# Altium schematic sheet Power12_2.SchDoc
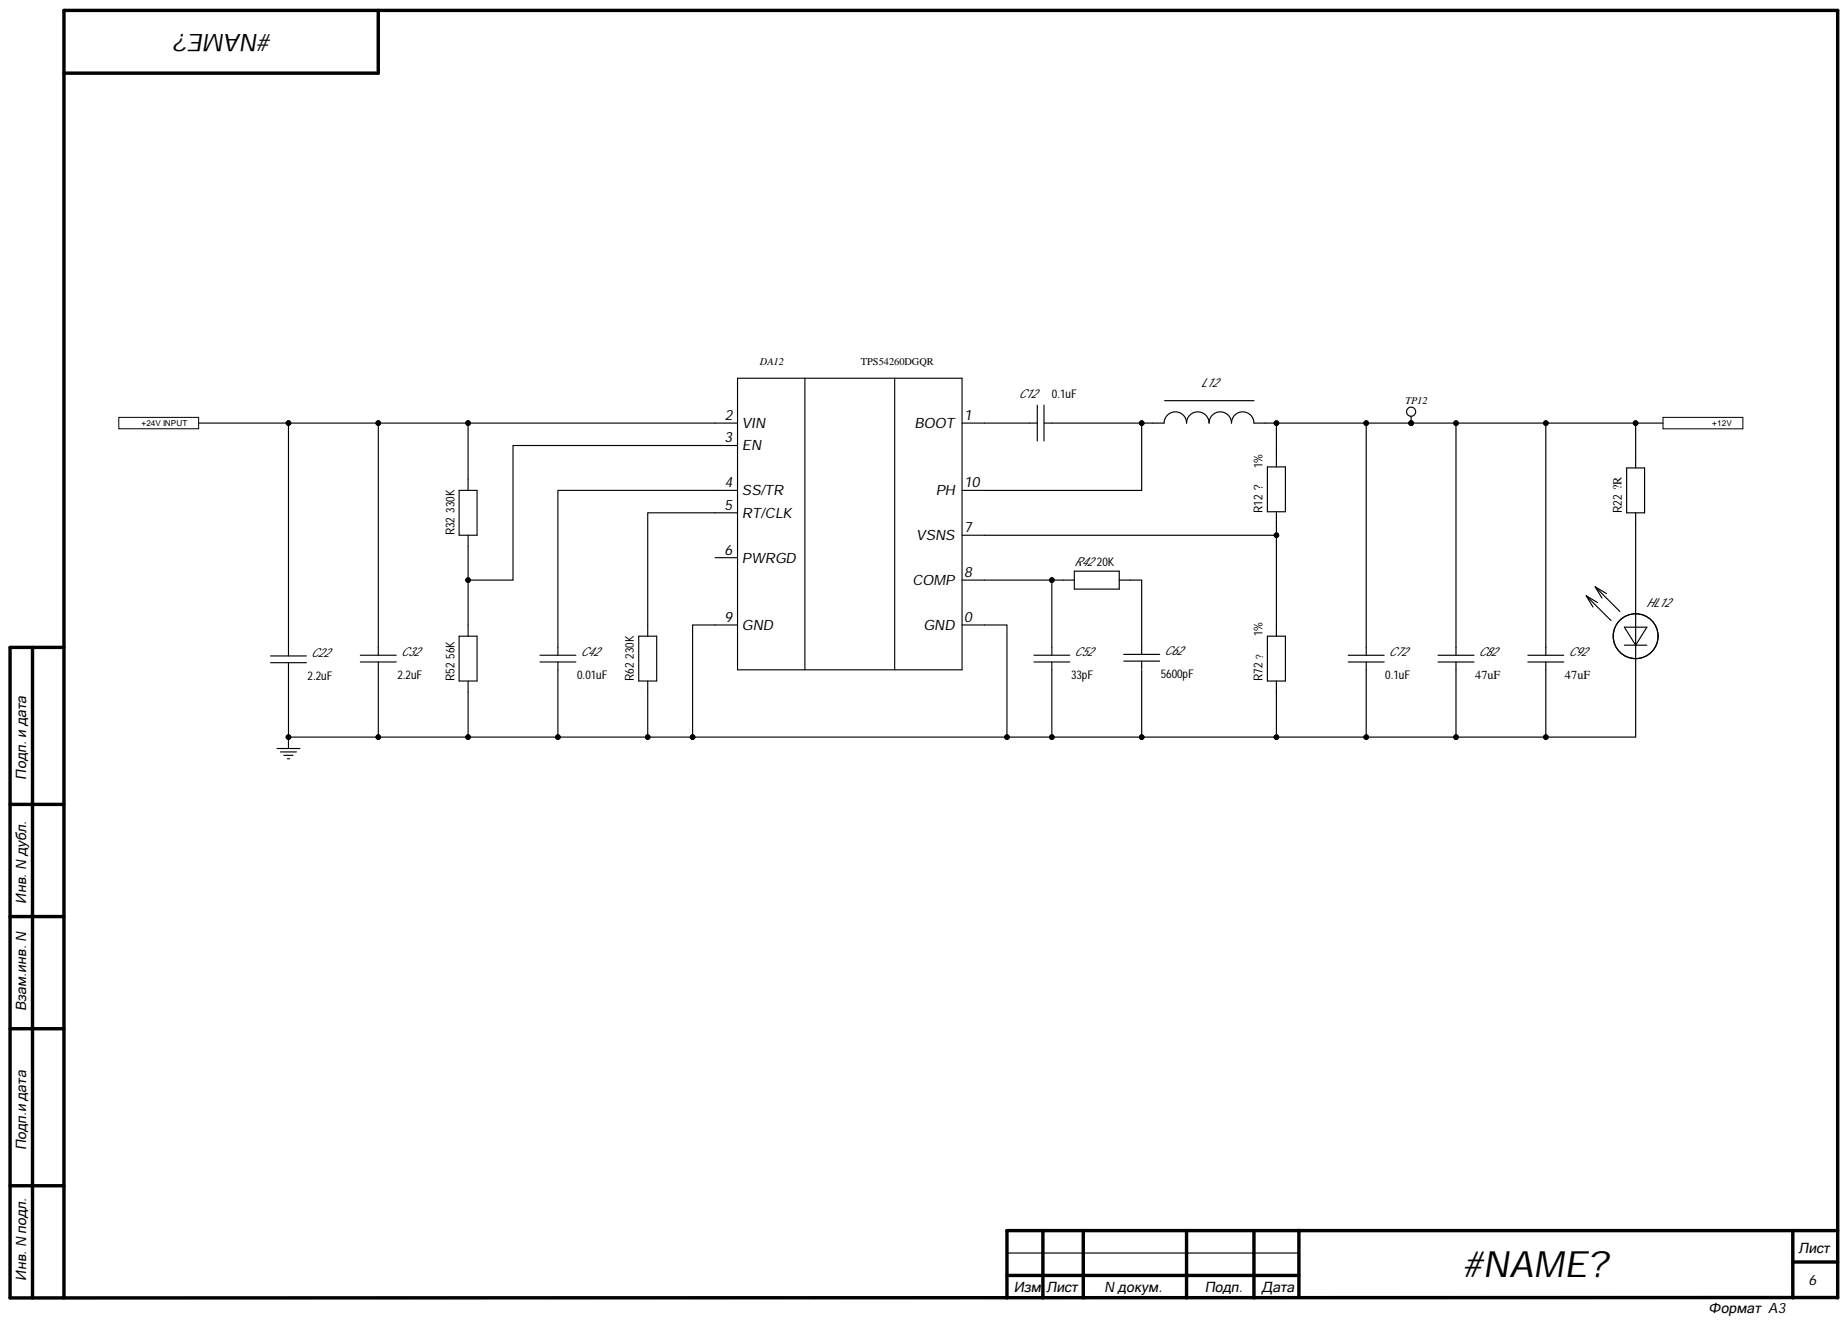
[diagram p0: Power12_2.SchDoc]

## Parts
C12  value 0.1uF  (hidden comment: Cap)
C22  value 2.2uF  (hidden comment: Cap)
C32  value 2.2uF  (hidden comment: Cap)
C42  value 0.01uF  (hidden comment: Cap)
C52  value 33pF  (hidden comment: Cap)
C62  value 5600pF  (hidden comment: Cap)
C72  value 0.1uF  (hidden comment: Cap)
C82  value 47uF  (hidden comment: Cap)
C92  value 47uF  (hidden comment: Cap)
DA12  TPS54260DGQR
HL12  (no value)
L12  (no value)
R12  Precision: 1%  (hidden comment: Res2)
R22  value ?R  (hidden comment: Res2)
R32  value 330K  (hidden comment: Res2)
R42  R?: 20K  (hidden comment: Res2)
R52  value 56K  (hidden comment: Res2)
R62  value 230K  (hidden comment: Res2)
R72  Precision: 1%  (hidden comment: Res2)
TP12  (no value)  [TP_0MM5_1MM0]  (no BOM)

## Ports
+12V, +24V INPUT

## Nets
GND: C22.2 C32.2 C42.2 C52.2 C72.2 C82.2 C92.2 DA12.0(GND) DA12.9(GND) HL12.2 R52.2 R62.2 R72.1
+12V: C72.1 C82.1 C92.1 L12.2 R12.2 R22.1 TP12.1 ; port +12V
+24V INPUT: C22.1 C32.1 DA12.2(VIN) R32.1 ; port +24V INPUT
NetC12_1: C12.1 DA12.1(BOOT)
NetC12_2: C12.2 DA12.10(PH) L12.1
NetC42_1: C42.1 DA12.4(SS/TR)
NetC52_1: C52.1 DA12.8(COMP) R42.2
NetC62_1: C62.1 R42.1
NetDA12_3: DA12.3(EN) R32.2 R52.1
NetDA12_5: DA12.5(RT/CLK) R62.1
NetDA12_7: DA12.7(VSNS) R12.1 R72.2
NetHL12_1: HL12.1 R22.2

Not connected: C62 2; DA12 6(PWRGD)

## Library data of the parts
C12 C22 C32 C42 C52 C62 C72 C82 C92 = Cap: Конденсатор; Component Kind: Standard; PackageReference: 0603; Signal Integrity: Cap; Library Name: Terra.SCHLIB
DA12 = TPS54260DGQR: Импульсный понижающий преобразователь 60V 2.5A; Component Kind: Standard; PackageReference: MSOP-10; Value: TPS54260DGQR; Library Name: Terra.SCHLIB
HL12 = DIODE-LED-GOST: Component Kind: Standard
L12 = Choke: Component Kind: Standard
R12 R22 R32 R42 R52 R62 R72 = Res2: Резистор; Component Kind: Standard; PackageReference: 0603; Signal Integrity: Res; Library Name: Terra.SCHLIB
  R12 R72: Value: ?
TP12 = Test_Point: Тестовая точка на плате; MarkPCB: +5V
